# Altium schematic sheet PowerCapacityModule.SchDoc(PowerCapacityModule)
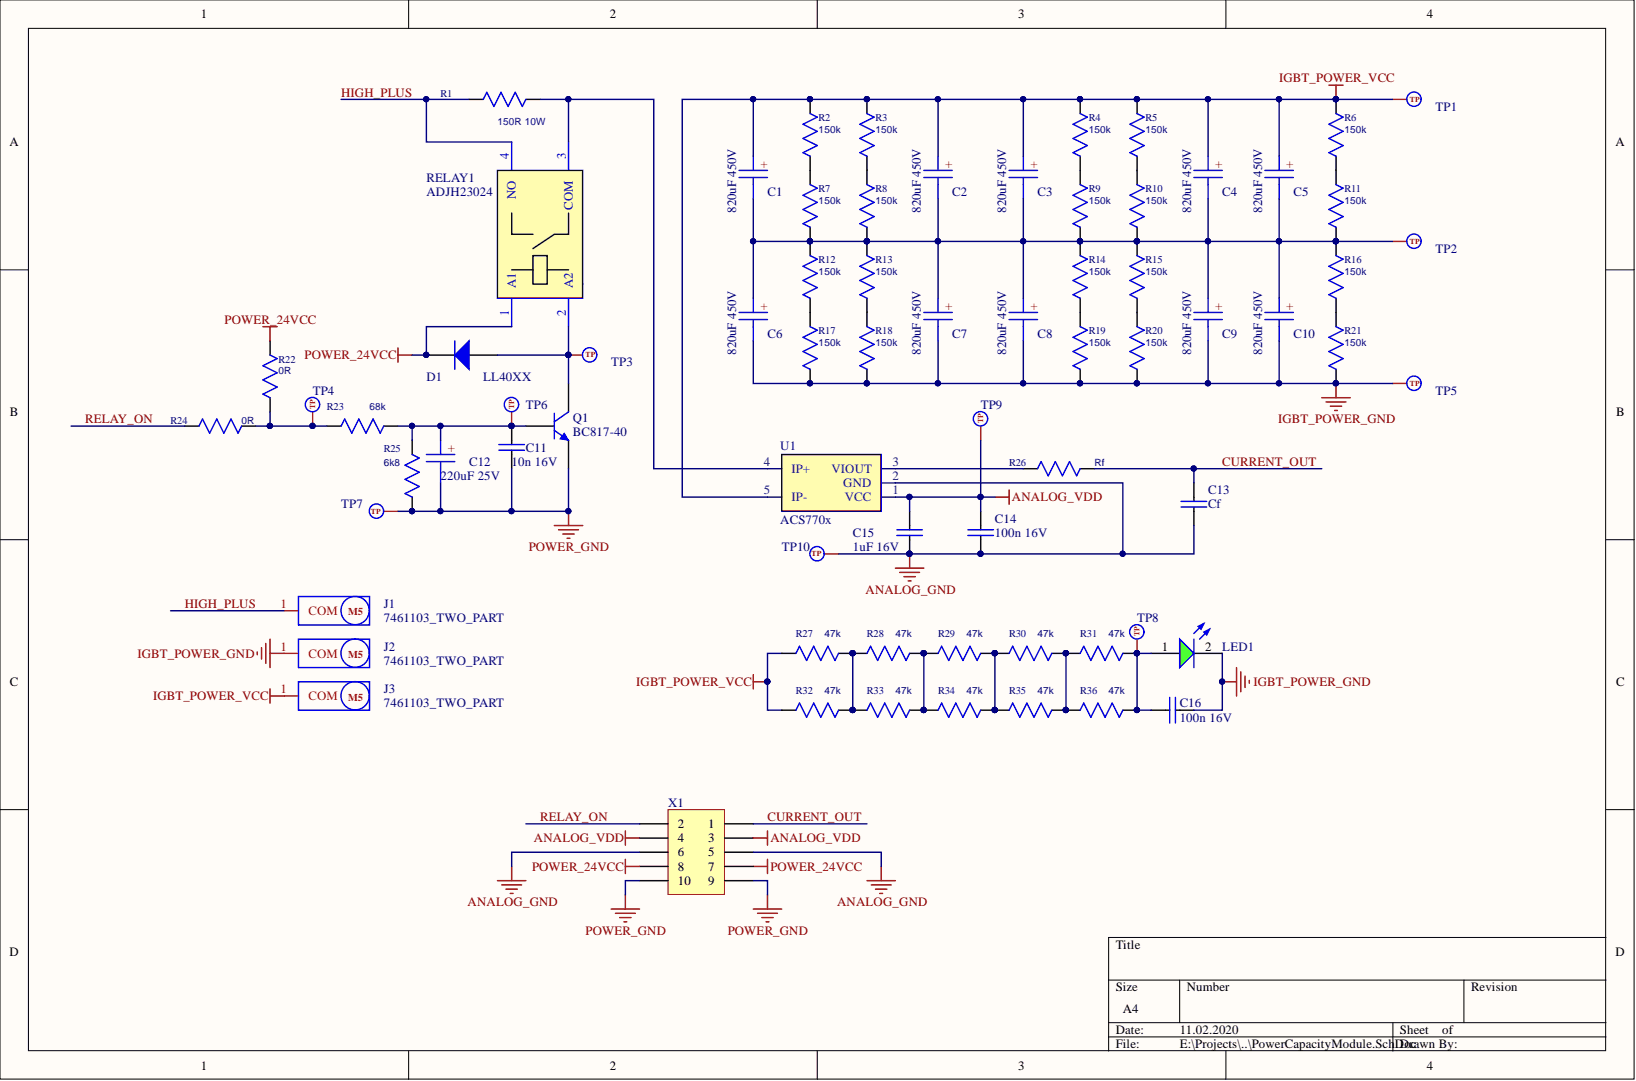
[diagram p0: PowerCapacityModule.SchDoc]

## Parts
C1  820uF 450V  [C_35x50x10]
C2  820uF 450V  [C_35x50x10]
C3  820uF 450V  [C_35x50x10]
C4  820uF 450V  [C_35x50x10]
C5  820uF 450V  [C_35x50x10]
C6  820uF 450V  [C_35x50x10]
C7  820uF 450V  [C_35x50x10]
C8  820uF 450V  [C_35x50x10]
C9  820uF 450V  [C_35x50x10]
C10  820uF 450V  [C_35x50x10]
C11  10n 16V  [C_0805]
C12  220uF 25V  [C_8x11.5x3.5]
C13  Cf  [C_0805]
C14  100n 16V  [C_0805]
C15  1uF 16V  [C_0805]
C16  100n 16V  [C_0805]
D1  LL40XX  [DO-213AB]
J1  7461103_TWO_PART  [7461103_TWO_PART]
J2  7461103_TWO_PART  [7461103_TWO_PART]
J3  7461103_TWO_PART  [7461103_TWO_PART]
LED1  Green  [WP934CB/GD]  (value not drawn)
Q1  BC817-40  [SOT23-3]
R1  150R 10W  [AXIAL_10W]
R2  150k  [R_1210]
R3  150k  [R_1210]
R4  150k  [R_1210]
R5  150k  [R_1210]
R6  150k  [R_1210]
R7  150k  [R_1210]
R8  150k  [R_1210]
R9  150k  [R_1210]
R10  150k  [R_1210]
R11  150k  [R_1210]
R12  150k  [R_1210]
R13  150k  [R_1210]
R14  150k  [R_1210]
R15  150k  [R_1210]
R16  150k  [R_1210]
R17  150k  [R_1210]
R18  150k  [R_1210]
R19  150k  [R_1210]
R20  150k  [R_1210]
R21  150k  [R_1210]
R22  0R  [R_0805]
R23  68k  [R_0805]
R24  0R  [R_0805]
R25  6k8  [R_0805]
R26  Rf  [R_0805]
R27  47k  [R_0805]
R28  47k  [R_0805]
R29  47k  [R_0805]
R30  47k  [R_0805]
R31  47k  [R_0805]
R32  47k  [R_0805]
R33  47k  [R_0805]
R34  47k  [R_0805]
R35  47k  [R_0805]
R36  47k  [R_0805]
RELAY1  ADJH23024  [ADJH23024]
TP1  TEST_POINT  [TEST POINT D1.5]  (value not drawn)
TP2  TEST_POINT  [TEST POINT D1.5]  (value not drawn)
TP3  TEST_POINT  [TEST POINT D1.5]  (value not drawn)
TP4  TEST_POINT  [TEST POINT D1.5]  (value not drawn)
TP5  TEST_POINT  [TEST POINT D1.5]  (value not drawn)
TP6  TEST_POINT  [TEST POINT D1.5]  (value not drawn)
TP7  TEST_POINT  [TEST POINT D1.5]  (value not drawn)
TP8  TEST_POINT  [TEST POINT D1.5]  (value not drawn)
TP9  TEST_POINT  [TEST POINT D1.5]  (value not drawn)
TP10  TEST_POINT  [TEST POINT D1.5]  (value not drawn)
U1  ACS770x  [CB-5-PFF]
X1  PLD-10R  [PLD-10RR2]  (value not drawn)

## Nets
ANALOG_GND: C13.1 C14.1 C15.1 TP10.1 U1.2(GND) X1.5 X1.6
ANALOG_VDD: C14.2 C15.2 TP9.1 U1.1(VCC) X1.3 X1.4
IGBT_POWER_GND: C6.2 C7.2 C8.2 C9.2 C10.2 C16.1 J2.1 LED1.2 R17.2 R18.2 R19.2 R20.2 R21.2 TP5.1
IGBT_POWER_VCC: C1.1 C2.1 C3.1 C4.1 C5.1 J3.1 R2.1 R3.1 R4.1 R5.1 R6.1 R27.2 R32.2 TP1.1 U1.5(IP-)
POWER_24VCC: D1.2 R22.2 RELAY1.1(A1) X1.7 X1.8
POWER_GND: C11.2 C12.2 Q1.2 R25.1 TP7.1 X1.9 X1.10
CURRENT_OUT: C13.2 R26.1 X1.1
HIGH_PLUS: J1.1 R1.2 RELAY1.4(NO)
RELAY_ON: R24.2 X1.2
NetC1_2: C1.2 C2.2 C3.2 C4.2 C5.2 C6.1 C7.1 C8.1 C9.1 C10.1 R7.2 R8.2 R9.2 R10.2 R11.2 R12.1 R13.1 R14.1 R15.1 R16.1 TP2.1
NetC11_1: C11.1 C12.1 Q1.1 R23.1 R25.2 TP6.1
NetC16_2: C16.2 LED1.1 R31.1 R36.1 TP8.1
NetD1_1: D1.1 Q1.3 RELAY1.2(A2) TP3.1
NetR1_1: R1.1 RELAY1.3(COM) U1.4(IP+)
NetR2_2: R2.2 R7.1
NetR3_2: R3.2 R8.1
NetR4_2: R4.2 R9.1
NetR5_2: R5.2 R10.1
NetR6_2: R6.2 R11.1
NetR12_2: R12.2 R17.1
NetR13_2: R13.2 R18.1
NetR14_2: R14.2 R19.1
NetR15_2: R15.2 R20.1
NetR16_2: R16.2 R21.1
NetR22_1: R22.1 R23.2 R24.1 TP4.1
NetR26_2: R26.2 U1.3(VIOUT)
NetR27_1: R27.1 R28.2 R32.1 R33.2
NetR28_1: R28.1 R29.2 R33.1 R34.2
NetR29_1: R29.1 R30.2 R34.1 R35.2
NetR30_1: R30.1 R31.2 R35.1 R36.2

## Library data of the parts
C1-C10 = RLA_SIZE_35x50x10: Aluminum Electrolytic Capacitors; Component Kind: Standard; Library Name: Capacitors.SchLib; Value: 820uF 450V
C11 C13-C16 = Cap NP: CERAMIC CAPACITOR; Component Kind: Standard; Library Name: Capacitors.SchLib
  C11: Value: 10n 16V
  C13: Value: Cf
  C14 C16: Value: 100n 16V
  C15: Value: 1uF 16V
C12 = WL_SIZE_8x11.5x3.5: Aluminum Electrolytic Capacitors; Component Kind: Standard; Library Name: Capacitors.SchLib; Value: 220uF 25V
D1 = LL40XX: Schottky Diode; Component Kind: Standard; Library Name: Diode.SchLib; Value: LL40XX
J1-J3 = 7461103_TWO_PART: TWO PART FOR TERM PWR ELEMENT M5 8 PIN PCB RA; System: Connector; Component Kind: Standard; Library Name: Connectors.SchLib; Value: 7461103_TWO_PART
LED1 = WP934CD/GB: LED array diameter 3.0mm Single; Color: Green; Component Kind: Standard; Library Name: Leds.SchLib; Value: WP934CD/GB
Q1 = BC817-40: NPN General-purpose Transistor; Component Kind: Standard; Library Name: Transistors.SchLib; Signal Integrity: BC817-40; Simulation: QBC817-40; Value: BC817-40
R1 = AXIAL: Resistor; Component Kind: Standard; Library Name: Resistors.SchLib; Value: 150R 10W
R2-R21 = R1210: Resistor; Component Kind: Standard; Library Name: Resistors.SchLib; Value: 150k
R22-R36 = R0805: Resistor; Component Kind: Standard; Library Name: Resistors.SchLib
  R22 R24: Value: 0R
  R23: Value: 68k
  R25: Value: 6k8
  R26: Value: Rf
  R27-R36: Value: 47k
RELAY1 = ADJH23024: RELAY GEN PURPOSE SPST 50A 24V; Component Kind: Standard; Library Name: Relay.SchLib; Value: ADJH23024
TP1-TP10 = TEST_POINT: Signal Test Point; Component Kind: Standard; Library Name: Discrete.SchLib
U1 = ACS770x: Thermally Enhanced, Fully Integrated, Hall-Effect-Based   High-Precision Linear Current Sensor IC with 100 µΩ Current Conductor; Component Kind: Standard; Library Name: CurrentSensor.SchLib; Value: ACS770x
X1 = PLD-10R: Header, 5-Pin, Dual row; System: Connector; Component Kind: Standard; Library Name: Connectors.SchLib
